# Altium schematic sheet 03.USB_CP2102.SchDoc
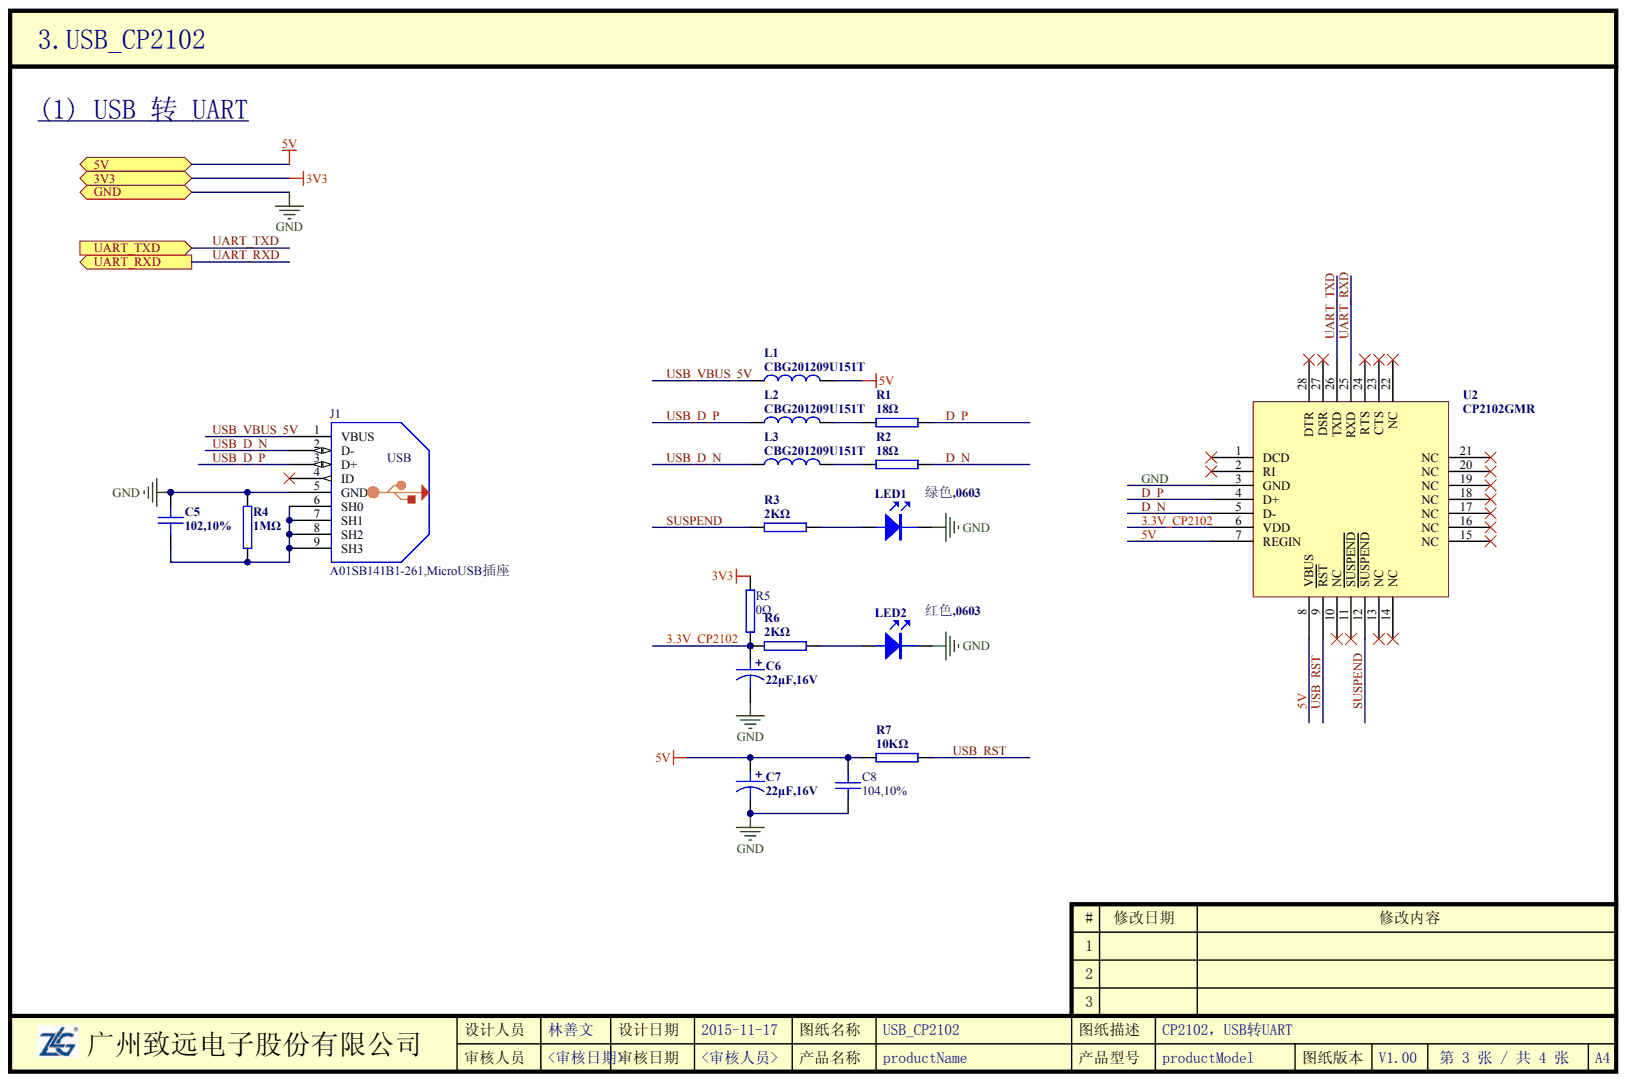
[diagram p0: 03.USB_CP2102.SchDoc]

## Parts
C5  102,±10%  (value not drawn)
C6  22μF,16V
C7  22μF,16V
C8  104,±10%  (value not drawn)
J1  value A01SB141B1-261,MicroUSB插座  (hidden comment: USB_MINIB_SMT)
L1  value CBG201209U151T  (hidden comment: INDUCTOR1)
L2  value CBG201209U151T  (hidden comment: INDUCTOR1)
L3  value CBG201209U151T  (hidden comment: INDUCTOR1)
LED1  LED1  value 绿色,0603
LED2  value 红色,0603  (hidden comment: LED1)
R1  18Ω
R2  18Ω
R3  2KΩ
R4  1MΩ
R5  0Ω
R6  2KΩ
R7  10KΩ
U2  CP2102  value CP2102GMR

## Ports
3V3, 5V, GND, UART_RXD, UART_TXD

## Nets
3.3V_CP2102: C6.1 R5.1 R6.1 U2.6(VDD)
3V3: R5.2 ; port 3V3
5V: C7.1 C8.1 L1.2 R7.2 U2.7(REGIN) U2.8(VBUS) ; port 5V
D_N: R2.2 U2.5(D-)
D_P: R1.2 U2.4(D+)
GND: C5.1 C6.2 C7.2 C8.2 J1.5(GND) LED1.2 LED2.2 R4.1 U2.3(GND) ; port GND
SUSPEND: R3.1 U2.12(SUSPEND)
UART_RXD: U2.25(RXD) ; port UART_RXD
UART_TXD: U2.26(TXD) ; port UART_TXD
USB_D_N: J1.2(D-) L3.1
USB_D_P: J1.3(D+) L2.1
USB_RST: R7.1 U2.9(RST)
USB_VBUS_5V: J1.1(VBUS) L1.1
NetC5_2: C5.2 J1.6(SH0) J1.7(SH1) J1.8(SH2) J1.9(SH3) R4.2
NetL2_2: L2.2 R1.1
NetL3_2: L3.2 R2.1
NetLED1_1: LED1.1 R3.2
NetLED2_1: LED2.1 R6.2

Not connected: J1 4(ID); U2 1(DCD) 2(RI) 10(NC) 11(SUSPEND) 13(NC) 14(NC) 15(NC) 16(NC) 17(NC) 18(NC) 19(NC) 20(NC) 21(NC) 22(NC) 23(CTS) 24(RTS) 27(DSR) 28(DTR)

## Library data of the parts
C5 = (no symbol name): 物料代码: 1.02.03.0003; 名称: 电容; 封装: 1206; 设备封装名称: C1206_102_X7R_2KV_10%; 型号: 102,±10%,2KV,X7R; 贴片和直插: SMT; Value: 102,±10%; RoHS: RoHS; ComponentLink1Description: ErpDataInfo; ComponentLink1URL: http://erp.zlgmcu.com/ErpExt/ErpData/ListMateriel.aspx?pkey=1.02.03.0003
C6 C7 = (no symbol name): 物料代码: 1.02.05.0015; 名称: 钽电容; 设备封装名称: B_22uF_16V; 厂商: AVX; 型号: 22μF,16V,B型; 贴片和直插: SMT; 器件优选方案: 优先选用; Value: 22μF,16V; RoHS: RoHS; ComponentLink1Description: ErpDataInfo; ComponentLink1URL: http://erp.zlgmcu.com/ErpExt/ErpData/ListMateriel.aspx?pkey=1.02.05.0015
C8 = (no symbol name): 物料代码: 1.02.01.0032; 名称: 电容; 封装: 0603; 设备封装名称: C0603_104_X7R_50V_10%; 型号: 104,±10%,50V,X7R,0603,*,RoHS; 贴片和直插: SMT; Value: 104,±10%; RoHS: RoHS; ComponentLink1Description: ErpDataInfo; ComponentLink1URL: http://erp.zlgmcu.com/ErpExt/ErpData/ListMateriel.aspx?pkey=1.02.01.0032
J1 = (no symbol name): Text Field1: 100; Text Field2: 80; 物料代码: 1.10.12.0014; Value: A01SB141B1-261,MicroUSB插座; 名称: MicroUSB插座; 封装: SMT; 厂商: 艾立信; PCB备注: 141030lmd; 器件优选方案: 样品物料,谨慎选用; RoHS: RoHS; 型号: A01SB141B1-261,MicroUSB插座; 贴片和直插: SMT; ComponentLink1Description: ErpDataInfo; ComponentLink1URL: http://erp.zlgmcu.com/ErpExt/ErpData/ListMateriel.aspx?pkey=1.10.12.0014
L1-L3 = (no symbol name): 物料代码: 1.03.03.0001; 名称: 磁珠; 封装: 0805; 设备封装名称: FB0805_151T; 贴片和直插: SMT; RoHS: RoHS; 型号: CBG201209U151T; ComponentLink1Description: ErpDataInfo; ComponentLink1URL: http://erp.zlgmcu.com/ErpExt/ErpData/ListMateriel.aspx?pkey=1.03.03.0001
LED1 = (no symbol name): 物料代码: 1.04.05.0009; Value: 绿色,0603; 名称: 发光二极管; 封装: 0603; 设备封装名称: LED0603-GREEN; 贴片和直插: SMT; 器件优选方案: 优先选用; RoHS: RoHS; 型号: 绿色,0603; ComponentLink1Description: ErpDataInfo; ComponentLink1URL: http://erp.zlgmcu.com/ErpExt/ErpData/ListMateriel.aspx?pkey=1.04.05.0009
LED2 = (no symbol name): 物料代码: 1.04.05.0008; Value: 红色,0603; 名称: 发光二极管; 封装: 0603; 设备封装名称: LED0603-RED; 贴片和直插: SMT; 器件优选方案: 优先选用; RoHS: RoHS; 型号: 红色,0603; ComponentLink1Description: ErpDataInfo; ComponentLink1URL: http://erp.zlgmcu.com/ErpExt/ErpData/ListMateriel.aspx?pkey=1.04.05.0008
R1 R2 = (no symbol name): 物料代码: 1.01.01.0008; 名称: 电阻; 封装: 0603; 设备封装名称: R0603_18R; 贴片和直插: SMT; Value: 18Ω; RoHS: RoHS; 型号: 18Ω; ComponentLink1Description: ErpDataInfo; ComponentLink1URL: http://erp.zlgmcu.com/ErpExt/ErpData/ListMateriel.aspx?pkey=1.01.01.0008
R3 R6 = (no symbol name): 物料代码: 1.01.01.0038; 名称: 电阻; 封装: 0603; 设备封装名称: R0603_2K; 贴片和直插: SMT; Value: 2KΩ; RoHS: RoHS; 型号: 2KΩ; ComponentLink1Description: ErpDataInfo; ComponentLink1URL: http://erp.zlgmcu.com/ErpExt/ErpData/ListMateriel.aspx?pkey=1.01.01.0038
R4 = (no symbol name): 物料代码: 1.01.03.0019; 名称: 电阻; 封装: 1206; 设备封装名称: R1206_1M; 贴片和直插: SMT; Value: 1MΩ; RoHS: RoHS; 型号: 1MΩ; ComponentLink1Description: ErpDataInfo; ComponentLink1URL: http://erp.zlgmcu.com/ErpExt/ErpData/ListMateriel.aspx?pkey=1.01.03.0019
R5 = (no symbol name): 物料代码: 1.01.01.0001; 名称: 电阻; 封装: 0603; 设备封装名称: R0603_0R; 贴片和直插: SMT; 器件优选方案: 02; Value: 0Ω; RoHS: RoHS; 型号: 0Ω; ComponentLink1Description: ErpDataInfo; ComponentLink1URL: http://erp.zlgmcu.com/ErpExt/ErpData/ListMateriel.aspx?pkey=1.01.01.0001
R7 = (no symbol name): 物料代码: 1.01.01.0051; 名称: 电阻; 封装: 0603; 设备封装名称: R0603_10K; 贴片和直插: SMT; Value: 10KΩ; RoHS: RoHS; 型号: 10KΩ; ComponentLink1Description: ErpDataInfo; ComponentLink1URL: http://erp.zlgmcu.com/ErpExt/ErpData/ListMateriel.aspx?pkey=1.01.01.0051
U2 = (no symbol name): 物料代码: 1.05.08.0037; 名称: IC; 封装: QFN-28; 设备封装名称: QFN-28_CP2102GMR; 厂商: SILICON LABDRATDRIES; 贴片和直插: SMT; 型号: CP2102GMR; ComponentLink1Description: ErpDataInfo; ComponentLink1URL: http://erp.zlgmcu.com/ErpExt/ErpData/ListMateriel.aspx?pkey=1.05.08.0037
